# Altium schematic sheet POWER.SchDoc
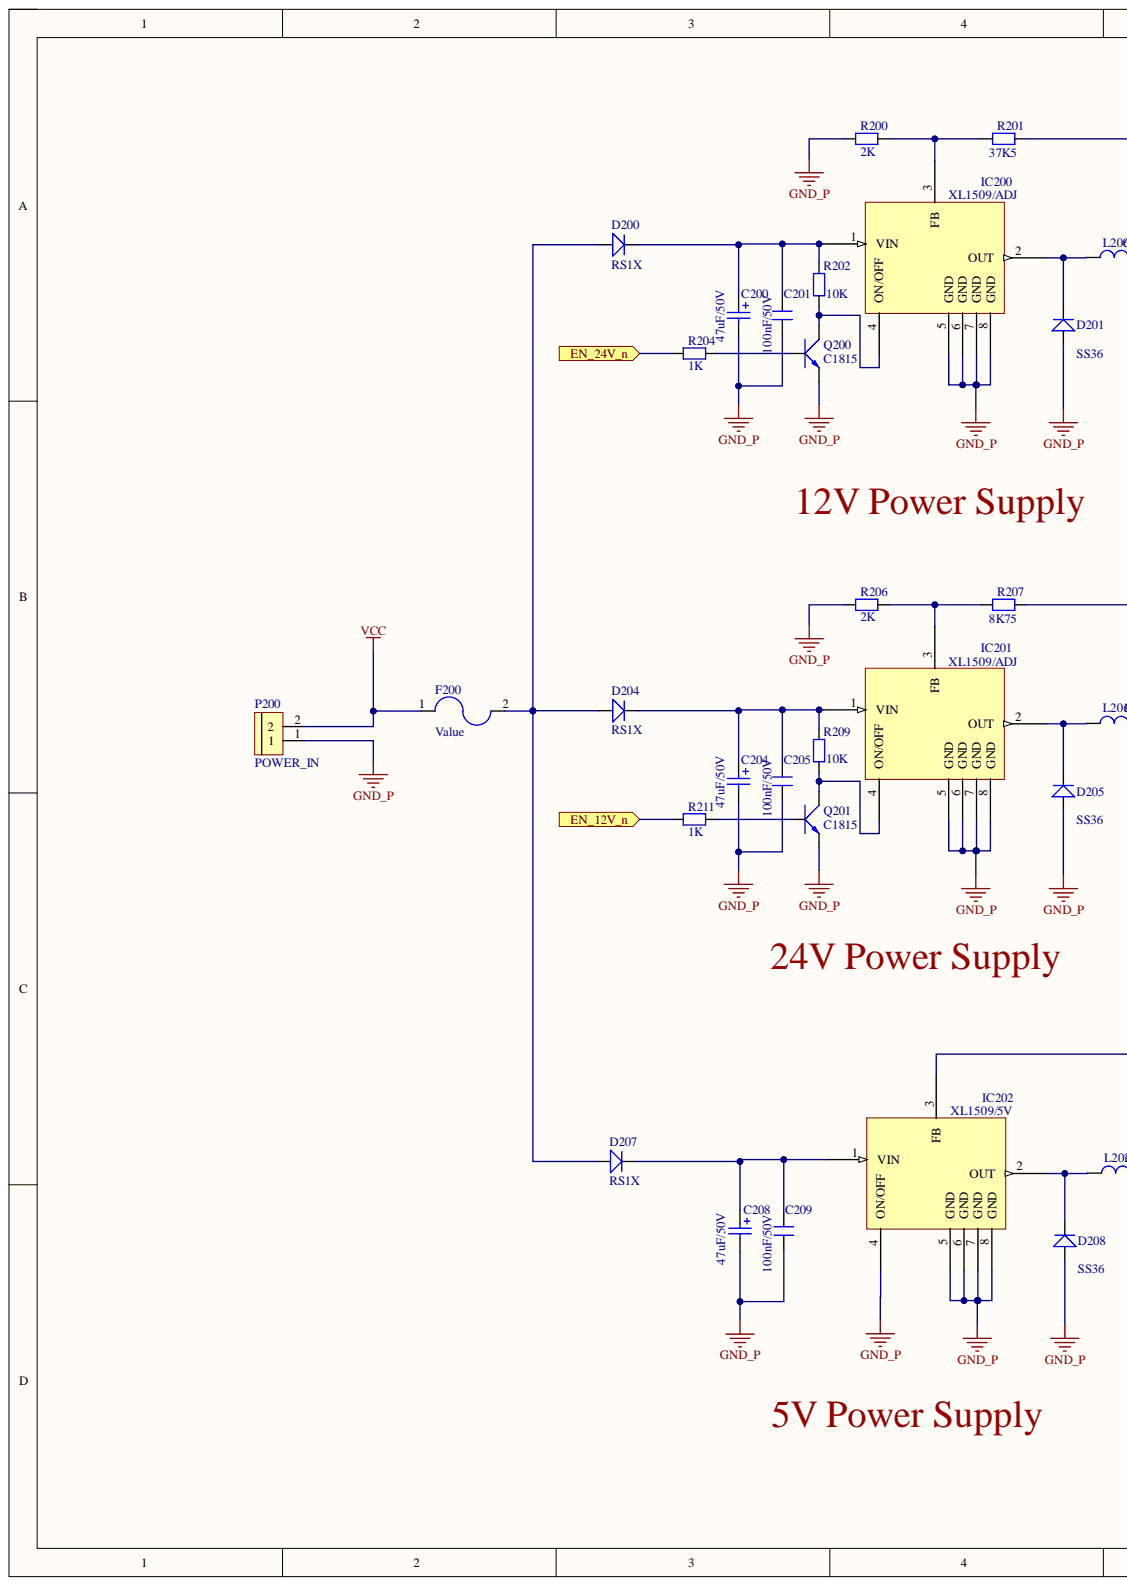
[diagram p0: POWER.SchDoc, left]
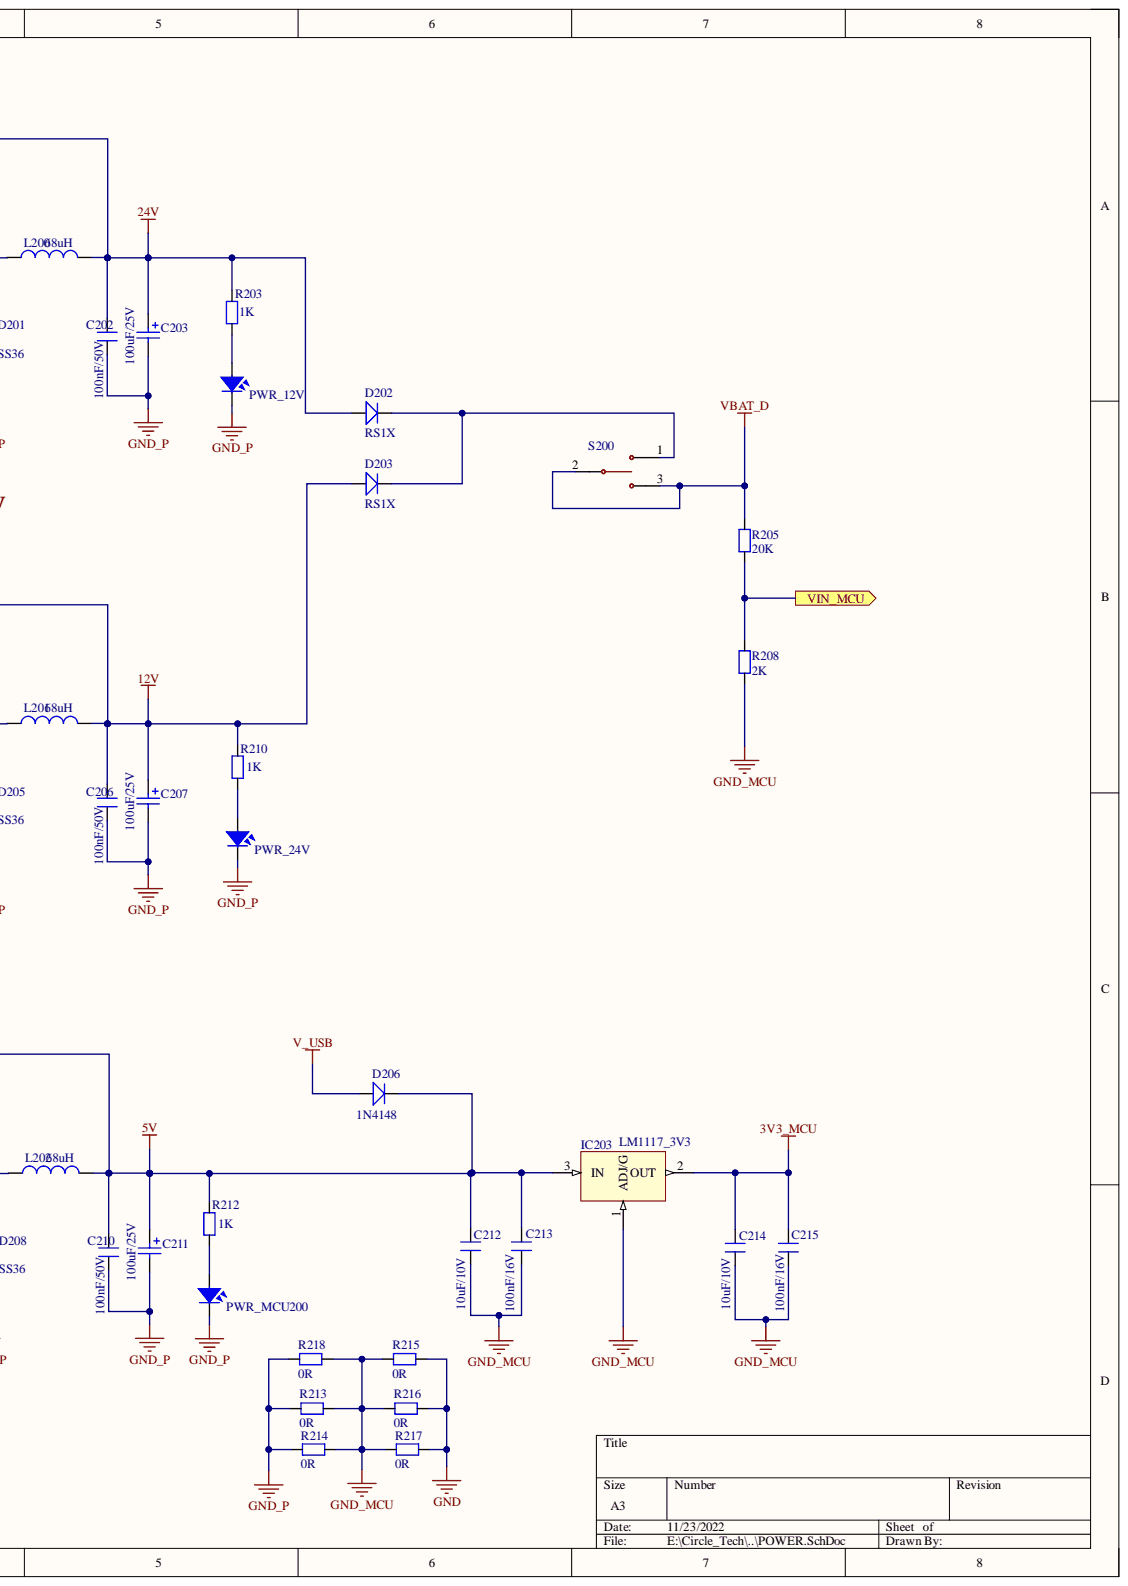
[diagram p1: POWER.SchDoc, right]

## Parts
C200  47uF/50V  [SMD_ALU_CAP_D6.3H7.7]
C201  value 100nF/50V  [C0603]  (hidden comment: C0603_X5R_±10%)
C202  value 100nF/50V  [C0603]  (hidden comment: C0603_X5R_±10%)
C203  100uF/25V  [SMD_ALU_CAP_D6.3H7.7]
C204  47uF/50V  [SMD_ALU_CAP_D6.3H7.7]
C205  value 100nF/50V  [C0603]  (hidden comment: C0603_X5R_±10%)
C206  value 100nF/50V  [C0603]  (hidden comment: C0603_X5R_±10%)
C207  100uF/25V  [SMD_ALU_CAP_D6.3H7.7]
C208  47uF/50V  [SMD_ALU_CAP_D6.3H7.7]
C209  value 100nF/50V  [C0603]  (hidden comment: C0603_X5R_±10%)
C210  value 100nF/50V  [C0603]  (hidden comment: C0603_X5R_±10%)
C211  100uF/25V  [SMD_ALU_CAP_D6.3H7.7]
C212  value 10uF/10V  [C0805]  (hidden comment: C0805 X5R ±10%)
C213  value 100nF/16V  [C0603]  (hidden comment: C0603_X5R_±10%)
C214  value 10uF/10V  [C0805]  (hidden comment: C0805 X5R ±10%)
C215  value 100nF/16V  [C0603]  (hidden comment: C0603_X5R_±10%)
D200  RS1X  [SMA_DO-214AC]
D201  value SS36  [SMA_DO-214AC]  (hidden comment: Diode_SMA)
D202  RS1X  [SMA_DO-214AC]
D203  RS1X  [SMA_DO-214AC]
D204  RS1X  [SMA_DO-214AC]
D205  value SS36  [SMA_DO-214AC]  (hidden comment: Diode_SMA)
D206  value 1N4148  [D_SOD123FL]  (hidden comment: Diode_SOD123FL)
D207  RS1X  [SMA_DO-214AC]
D208  value SS36  [SMA_DO-214AC]  (hidden comment: Diode_SMA)
F200  Fuse_Nano2  [Fuse_Nano2]  (value not drawn)
IC200  XL1509  value XL1509/ADJ  [SOIC-8]
IC201  XL1509  value XL1509/ADJ  [SOIC-8]
IC202  XL1509  value XL1509/5V  [SOIC-8]
IC203  LM1117_3V3  [SOT223_N]
L200  68uH  [*INDM7676X435N]
L201  68uH  [*INDM7676X435N]
L202  68uH  [*INDM7676X435N]
P200  POWER_IN  [BIG_Header 2]
PWR_12V  LED SMD  [SM-LED-0805-Green]  (value not drawn)
PWR_24V  LED SMD  [SM-LED-0805-Green]  (value not drawn)
PWR_MCU200  LED SMD  [SM-LED-0805-Green]  (value not drawn)
Q200  C1815  [SOT23B]
Q201  C1815  [SOT23B]
R200  value 2K  [R0603]  (hidden comment: Value)
R201  value 37K5  [R0603]  (hidden comment: Value)
R202  value 10K  [R0603]  (hidden comment: Value)
R203  1K  [R0805]
R204  value 1K  [R0603]  (hidden comment: Value)
R205  value 20K  [R0603]  (hidden comment: Value)
R206  value 2K  [R0603]  (hidden comment: Value)
R207  value 8K75  [R0603]  (hidden comment: Value)
R208  value 2K  [R0603]  (hidden comment: Value)
R209  value 10K  [R0603]  (hidden comment: Value)
R210  1K  [R0805]
R211  value 1K  [R0603]  (hidden comment: Value)
R212  1K  [R0805]
R213  0R  [R1206]
R214  0R  [R1206]
R215  0R  [R1206]
R216  0R  [R1206]
R217  0R  [R1206]
R218  0R  [R1206]
S200  100SP1T1B4M2QE  [Toggle_SW_DIP]  (value not drawn)

## Ports
EN_12V_n, EN_24V_n, VIN_MCU

## Nets
3V3_MCU: C214.2 C215.2 IC203.2
5V: C210.2 C211.1 C212.2 C213.2 D206.2 IC202.3(FB) IC203.3 L202.2 R212.2
12V: C206.2 C207.1 D203.1 L201.2 R207.2 R210.2
24V: C202.2 C203.1 D202.1 L200.2 R201.2 R203.2
GND: R215.1 R216.1 R217.1
GND_MCU: C212.1 C213.1 C214.1 C215.1 IC203.1 R208.1 R213.2 R214.2 R215.2 R216.2 R217.2 R218.2
GND_P: C200.2 C201.1 C202.1 C203.2 C204.2 C205.1 C206.1 C207.2 C208.2 C209.1 C210.1 C211.2 D201.1 D205.1 D208.1 IC200.5(GND) IC200.6(GND) IC200.7(GND) IC200.8(GND) IC201.5(GND) IC201.6(GND) IC201.7(GND) IC201.8(GND) IC202.4(ON/OFF) IC202.5(GND) IC202.6(GND) IC202.7(GND) IC202.8(GND) P200.1 PWR_12V.2 PWR_24V.2 PWR_MCU200.2 Q200.1 Q201.1 R200.1 R206.1 R213.1 R214.1 R218.1
V_USB: D206.1
VBAT_D: R205.2 S200.2 S200.3
VCC: F200.1 P200.2
EN_12V_n: R211.1 ; port EN_12V_n
EN_24V_n: R204.1 ; port EN_24V_n
VIN_MCU: R205.1 R208.2 ; port VIN_MCU
NetC200_1: C200.1 C201.2 D200.2 IC200.1(VIN) R202.2
NetC204_1: C204.1 C205.2 D204.2 IC201.1(VIN) R209.2
NetC208_1: C208.1 C209.2 D207.2 IC202.1(VIN)
NetD200_1: D200.1 D204.1 D207.1 F200.2
NetD201_2: D201.2 IC200.2(OUT) L200.1
NetD202_2: D202.2 D203.2 S200.1
NetD205_2: D205.2 IC201.2(OUT) L201.1
NetD208_2: D208.2 IC202.2(OUT) L202.1
NetIC200_3: IC200.3(FB) R200.2 R201.1
NetIC200_4: IC200.4(ON/OFF) Q200.2 R202.1
NetIC201_3: IC201.3(FB) R206.2 R207.1
NetIC201_4: IC201.4(ON/OFF) Q201.2 R209.1
NetPWR_12V_1: PWR_12V.1 R203.1
NetPWR_24V_1: PWR_24V.1 R210.1
NetPWR_MCU200_1: PWR_MCU200.1 R212.1
NetQ200_3: Q200.3 R204.2
NetQ201_3: Q201.3 R211.2

## Library data of the parts
C200 C203 C204 C207 C208 C211 = SMD_ALU_CAP: SMD Aluminum Electrolytic Capacitor; Manufacturer: Wurth Elektronik; Manufacturer Part Number: 865230140001; Capacitance: 22uF; Capacitance Tolerance: +/-20%; Dissipation Factor: 32%; Endurance: 5000h; Leakage Current: 3uA; Rated Voltage: 6.3VDC; Ripple Current: 22mA; Technical Reference: ASDB055220M6R3DVCTAE000; Component Kind: Standard; ComponentLink1Description: Manufacturer; ComponentLink1URL: http://www.we-online.de; ComponentLink2Description: Datasheet; ComponentLink2URL: https://www.we-online.com/catalog/datasheet/865230140001.pdf; Diameter: 4mm; Height: 5.5mm; Mounting Technology: SMT; Operating Temperature: -40 to +105degC; Packaging: Tape and Reel; Published Date: October 2020; Library Name: Daniel_Lib.IntLib
C201 C202 C205 C206 C209 C210 C213 C215 = C0603_X5R_±10%: SMD Ceramic Capacitor 0603 X5R ±10%; Component Kind: Standard; Component Type: Passive; Package: C0603; Library Name: Daniel_Lib.IntLib
C212 C214 = C0805 X5R ±10%: SMD Ceramic Capacitor 0805 X5R ±10%; Component Kind: Standard; Component Type: Passive; Package: C0805; Library Name: Daniel_Lib.IntLib
D200 D202-D204 D207 = Diode_RS1X: Diode Rectifier RS1X - 1A; Component Kind: Standard; Library Name: Daniel_Lib.IntLib
D201 D205 D208 = Diode_SMA: SMD Diode Rectìier SMA (DO-214AC); Component Kind: Standard; Component Type: Passive; Package: SMA (DO-214AC); Library Name: Daniel_Lib.IntLib
D206 = Diode_SOD123FL: SMD Diode Rectìier SOD123FL; Component Kind: Standard; Component Type: Passive; Package: SOD-123FL; Library Name: Daniel_Lib.IntLib
F200 = Fuse_Nano2: SMD LittelFuse Nano2, 65V, 20A,; Component Kind: Standard; Component Type: Passive; ComponentLink1Description: Datasheet; ComponentLink1URL: https://www.littelfuse.com/~/media/electronics/datasheets/fuses/littelfuse_fuse_456_datasheet.pdf.pdf; Value: Value; Library Name: Daniel_Lib.IntLib
IC200-IC202 = XL1509: Buck DC to DC Converter 2A 150KHz 40V; Manufacturer: XLSEMI; Manufacturer Part Number: XL1509-12E1; Component Kind: Standard; Component Type: IC Buck Converter; ComponentLink1Description: Datasheet; ComponentLink1URL: http://www.xlsemi.com/datasheet/XL1509%20datasheet.pdf; Library Name: IC.IntLib; Package: SOIC-8
IC203 = LM1117: Available in 1.8V, 2.5V, 2.85V, 3.3V, 5V, and  Adjustable Versions; Value: LM317; Component Kind: Standard; Library Name: TrieuLIB.IntLib
L200-L202 = Inductor: Code_IEC: 1005; Code_JEITA: 0402; Component Kind: Standard; Description: Inductor; LatestRevisionDate: 29-May-2009; LatestRevisionNote: IPC-7351 Footprint Added.; PackageDescription: Chip Inductor; PackageReference: 0402-A; Published: 8-Jun-2000; Library Name: TrieuLIB.IntLib; Publisher: Altium Limited
P200 = BIG_Header 2: Component Kind: Standard; Library Name: NBBLib 14-03-2012.IntLib
PWR_12V PWR_24V PWR_MCU200 = LED-SMD-0805: Component Kind: Standard; Library Name: syhaunguyen.IntLib
Q200 Q201 = C1815: NPN 600mW, ECB; Component Kind: Standard; Value: C1815; Library Name: syhaunguyen.IntLib
R200-R202 R204-R209 R211 = R0603: SMD Resistor 0603 0.1W 1%; Manufacturer: Uniohm; Component Kind: Standard; Component Type: Passive; Package: R0603; Library Name: Daniel_Lib.IntLib
R203 R210 R212 = R0805: Resistor SMD 0805 0.125W; Component Kind: Standard; Library Name: Daniel_Lib.IntLib
R213-R218 = R1206: Resistor SMD 1206 0.25W; Component Kind: Standard; Library Name: Daniel_Lib.IntLib
S200 = 100SP1T1B4M2QE: Toggle Switch SPDT Through Hole; Availability: Unavailable; Component Kind: Standard; Library Name: Relay.IntLib; MP: 100SP1T1B4M2QE; Package: None; Price: None
